# Altium schematic sheet POWER_SUPPLY.SchDoc
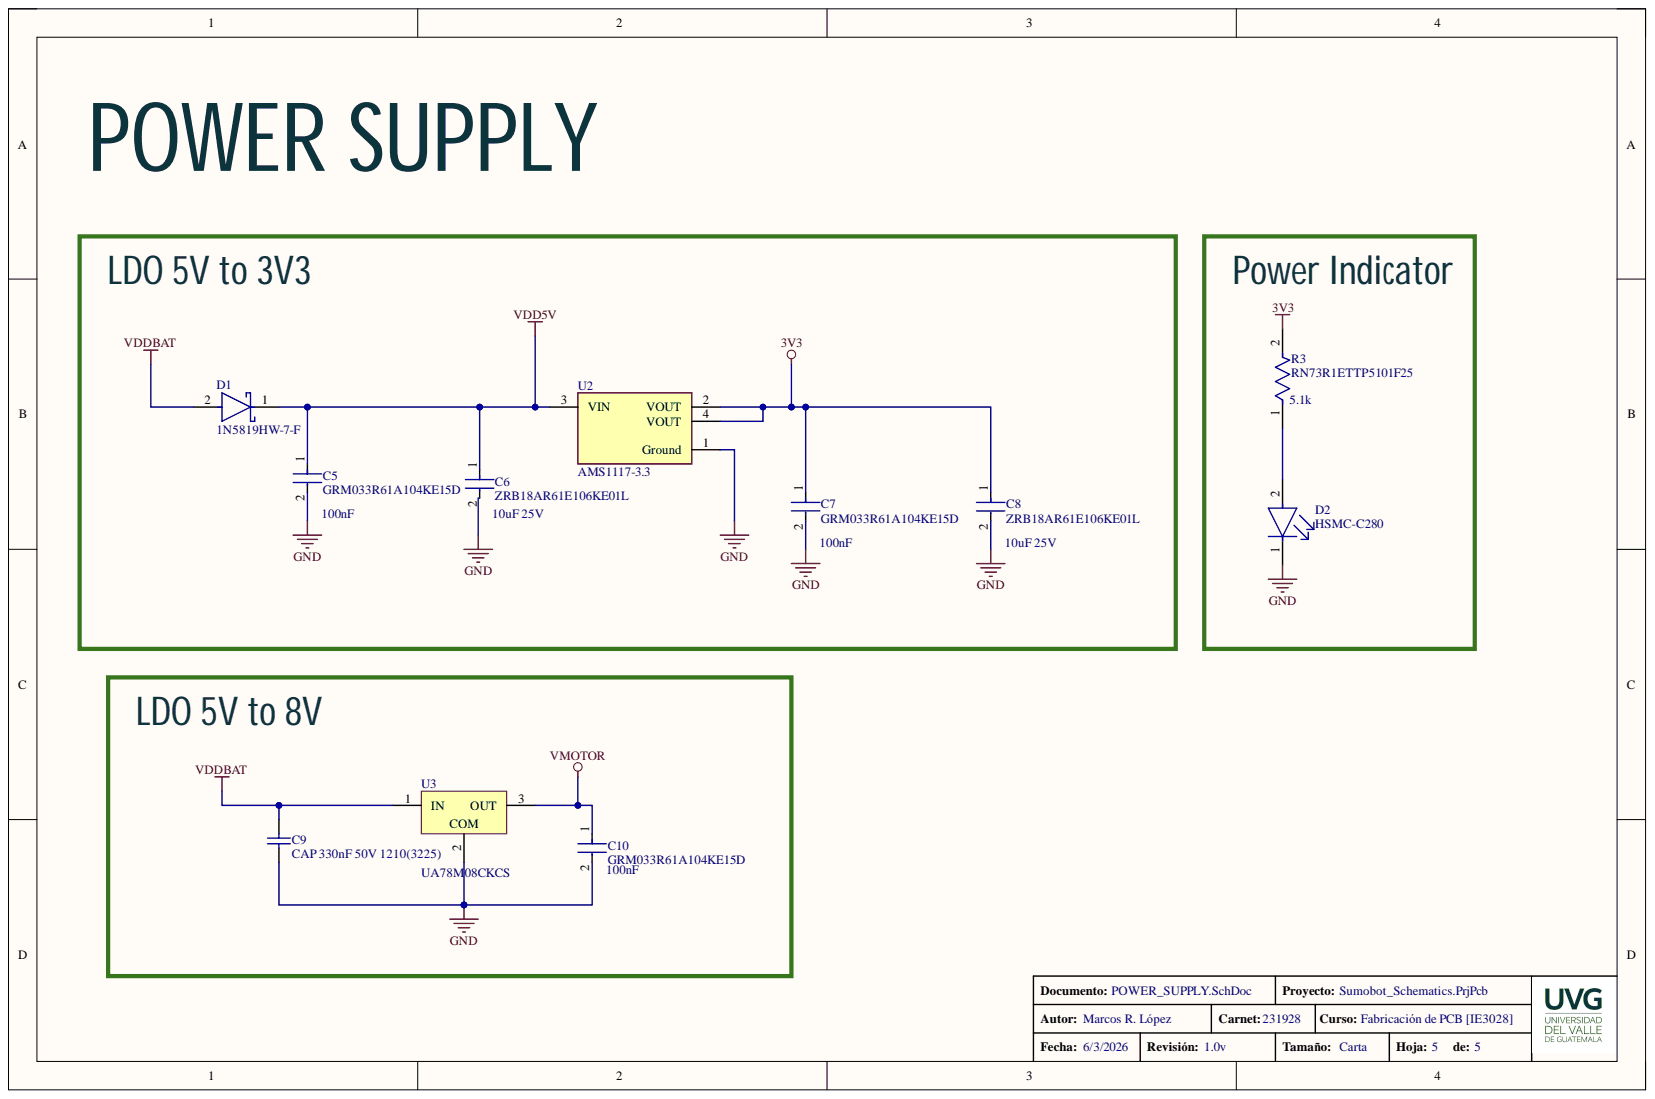
[diagram p0: POWER_SUPPLY.SchDoc]

## Parts
C5  GRM033R61A104KE15D  Capacitance: 100nF  [FP-GRM033-0_03-IPC_C]
C6  ZRB18AR61E106KE01L  value 10uF 25V  [FP-ZRB18A-0_2-MFG]
C7  GRM033R61A104KE15D  Capacitance: 100nF  [FP-GRM033-0_03-IPC_C]
C8  ZRB18AR61E106KE01L  value 10uF 25V  [FP-ZRB18A-0_2-MFG]
C9  CAP 330nF 50V 1210(3225)  value 330nF  [CAPC1210(3225)105_L]
C10  GRM033R61A104KE15D  Capacitance: 100nF  [FP-GRM033-0_03-IPC_C]
D1  1N5819HW-7-F  [FP-SOD123-IPC_A]
D2  HSMC-C280  [FP-HSMC-C280-MFG]
R3  RN73R1ETTP5101F25  value 5.1k  [FP-RN73R1E-IPC_C]
U2  AMS1117-3.3  [FP-AMS1117-IPC_C]
U3  UA78M08CKCS  [KCS0003A]

## Nets
3V3: C7.1 C8.1 R3.2 U2.2(VOUT) U2.4(VOUT)
GND: C5.2 C6.2 C7.2 C8.2 C9.1 C10.2 D2.1 U2.1(Ground) U3.2
VDD5V: C5.1 C6.1 D1.1 U2.3(VIN)
VDDBAT: C9.2 D1.2 U3.1
VMOTOR: C10.1 U3.3
NetD2_2: D2.2 R3.1

## Library data of the parts
C5 C7 C10 = CMP-2010-01264-2: Chip Multilayer Ceramic Capacitors for General Purpose, 0201, 0.10uF, X5R, 15%, 10%, 10V; Case Code (Imperial): 0201; Case Code (Metric): 0603; Case/Package: 0201; Component Kind: Standard; Depth: 0.3mm; Dielectric: X5R; Height: 0.3mm; Lead Free: Lead Free; Length: 0.6mm; Library Name: Altium Content Vault; Max Operating Temperature: 85°C; Min Operating Temperature: -55°C; Mount: Surface Mount; Package Quantity: 1; Packaging: Tape and Reel; Radiation Hardening: No; REACH SVHC: No SVHC; Termination: SMD/SMT; Thickness: 0.013inch; Tolerance: 10%; Voltage: 10V; Voltage Rating: 10V; Voltage Rating (DC): 10V; Weight: 6E-06oz; Width: 0.012inch
C6 C8 = CMP-06035-063177-1: Low Acoustic Noise Chip Multilayer Ceramic Capacitors on Interposer Board for General Purpose ZRB Series 0603 Package 1.2mm X5R @ 15% 25Vdc 10uF @ 10%; Component Kind: Standard; Library Name: Altium Content Vault
C9 = CMP-1038-01880-1: CAP 330nF 50V ±10% 1210 (3225 Metric) Thickness 1.05mm SMD; Case-EIA: 1210; Case-Metric: 3225; Tolerance: Â±10%; Component Kind: Standard; Library Name: Altium Content Vault; Max Thickness: 1.05 mm; Rated Voltage: 50 V; Technology: SMT
D1 = CMP-0303-00003-3: DIODE SCHOTTKY 40V 1A SOD123; Capacitance: 110pF; Element Configuration: Single; Forward Voltage: 450mV; Max Forward Surge Current (Ifsm): 25A; Max Power Dissipation: 450mW; Peak Reverse Current: 50uA; Polarity: Standard; Average Rectified Current: 1A; Case/Package: SOD-123-2; Component Kind: Standard; Contact Plating: Tin; Current Rating: 1A; Forward Current: 1A; Height: 1.25mm; Lead Free: Lead Free; Length: 2.85mm; Library Name: Altium Content Vault; Max Operating Temperature: 125°C; Max Repetitive Reverse Voltage (Vrrm): 40V; Max Reverse Voltage (DC): 40V; Min Operating Temperature: -65°C; Number of Pins: 2; Output Current: 1A; Package Quantity: 3000; Packaging: Tape and Reel; Peak Non-Repetitive Surge Current: 25A; Radiation Hardening: No; REACH SVHC: No SVHC; Termination: SMD/SMT; Voltage Rating (DC): 40V; Weight: 0.000353oz; Width: 1.7mm
D2 = CMP-18399-000022-1: LED RED DIFFUSED 0402 SMD; Dominant Wavelength: 626nm; Forward Current: 20mA; Forward Voltage: 1.9V; Lens Color: White; Luminous Flux: 155lm; Luminous Intensity: 90mcd; Max Power Dissipation: 60mW; Number of Elements: 1; Reverse Voltage: 5V; Viewing Angle: 130°; Case Code (Imperial): 0402; Case Code (Metric): 1005; Case/Package: 0402; Color: Red; Component Kind: Standard; Contact Plating: Gold; Depth: 0.5mm; Height: 0.4mm; Illumination Color: Red; Lead Free: Lead Free; Length: 1mm; Lens Style: Diffused; Lens Transparency: Diffused; Library Name: Altium Content Vault; Max Operating Temperature: 85°C; Min Operating Temperature: -40°C; Mount: Surface Mount; Number of LEDs: 1; Number of Pins: 2; Package Quantity: 4000; Packaging: Tape and Reel; Power Dissipation: 60mW; Power Rating: 60mW; Radiation Hardening: No; REACH SVHC: No SVHC; Test Current: 20mA; Wavelength: 626nm; Width: 0.5mm
R3 = CMP-04015-008529-1: 5.1kΩ ±1% 0.063W, 1/16W Chip Resistor 0402 (1005 Metric) Moisture Resistant Thin Film; Component Kind: Standard; Library Name: Altium Content Vault
U2 = CMP-209535-000001-1: LDO Voltage Regulators 1A, 3.3V; Manufacturer: Advanced Monolithic Systems; Dropout Voltage: 1.1V; Max Junction Temp: +125°C; Max Power Dissipation: 1.2W; Max Supply Voltage: 15V; Nominal Supply Current: 5mA; Number Of Outputs: 1; Output Type: Fixed; Standoff Height: 0.64mm; Automotive: No; Category: IC; Component Kind: Standard; ComponentLink2Description: Datasheet; ComponentLink2URL: http://www.advanced-monolithic.com/pdf/ds1117.pdf; Device Class L1: Integrated Circuits (ICs); Device Class L2: Power Management ICs; Device Class L3: Voltage Regulators - Linear; Height: 1.8mm; Ipc Land Pattern Name: SOT229P700X180-4; Lead Free: Yes; Library Name: Altium Content Vault; Manufacturer Part Number: AMS1117-3.3; Octopart: https://octopart.com/ams1117-3.3-advanced+monolithic+systems-25899300; Output Current: 1A; Output Voltage: 3.3V; Package: AMS1117; RoHS: TRUE; Temperature: -40°C to +125°C; Temperature Range High: +125°C; Temperature Range Low: -40°C
U3 = CMP-0324-00074-2: Fixed Regulator with 10.5 to 25 V Input and 8 V Output, 0 to 125 degC, 3-Pin TO-220 (KCS), Pb-Free (RoHS); Manufacturer: Texas Instruments; Iout(Max)(A): 0.5; Iq(Typ)(mA): 6; SubFamily: Standard Voltage Regulator; Vin(Max)(V): 25; Vin(Min)(V): 10.5; Vout(Max)(V): 8; Code_JEDEC: TO-226-AA; Component Kind: Standard; ComponentLink1Description: Datasheet; ComponentLink1URL: http://www.ti.com/general/docs/lit/getliterature.tsp?genericPartNumber=UA78M08&fileType=pdf; ComponentLink2Description: URL Link1; ComponentLink2URL: Samples URL:http://www.ti.com/product/ua78m08#samples; DatasheetVersion: SLVS059Q; Eco-Plan: Pb-Free (RoHS); Library Name: Altium Content Vault; Mounting Technology: Through Hole; PackageDescription: Plastic Cylindrical Package, Formed Lead Option (TO-92); PackageReference: KCS0003A; PackageVersion: revC, Oct-2001; PartNumber: UA78M08CKCS; Vout(Min)(V): 8
